# Altium schematic sheet GPS Board.SchDoc("GPS Board")
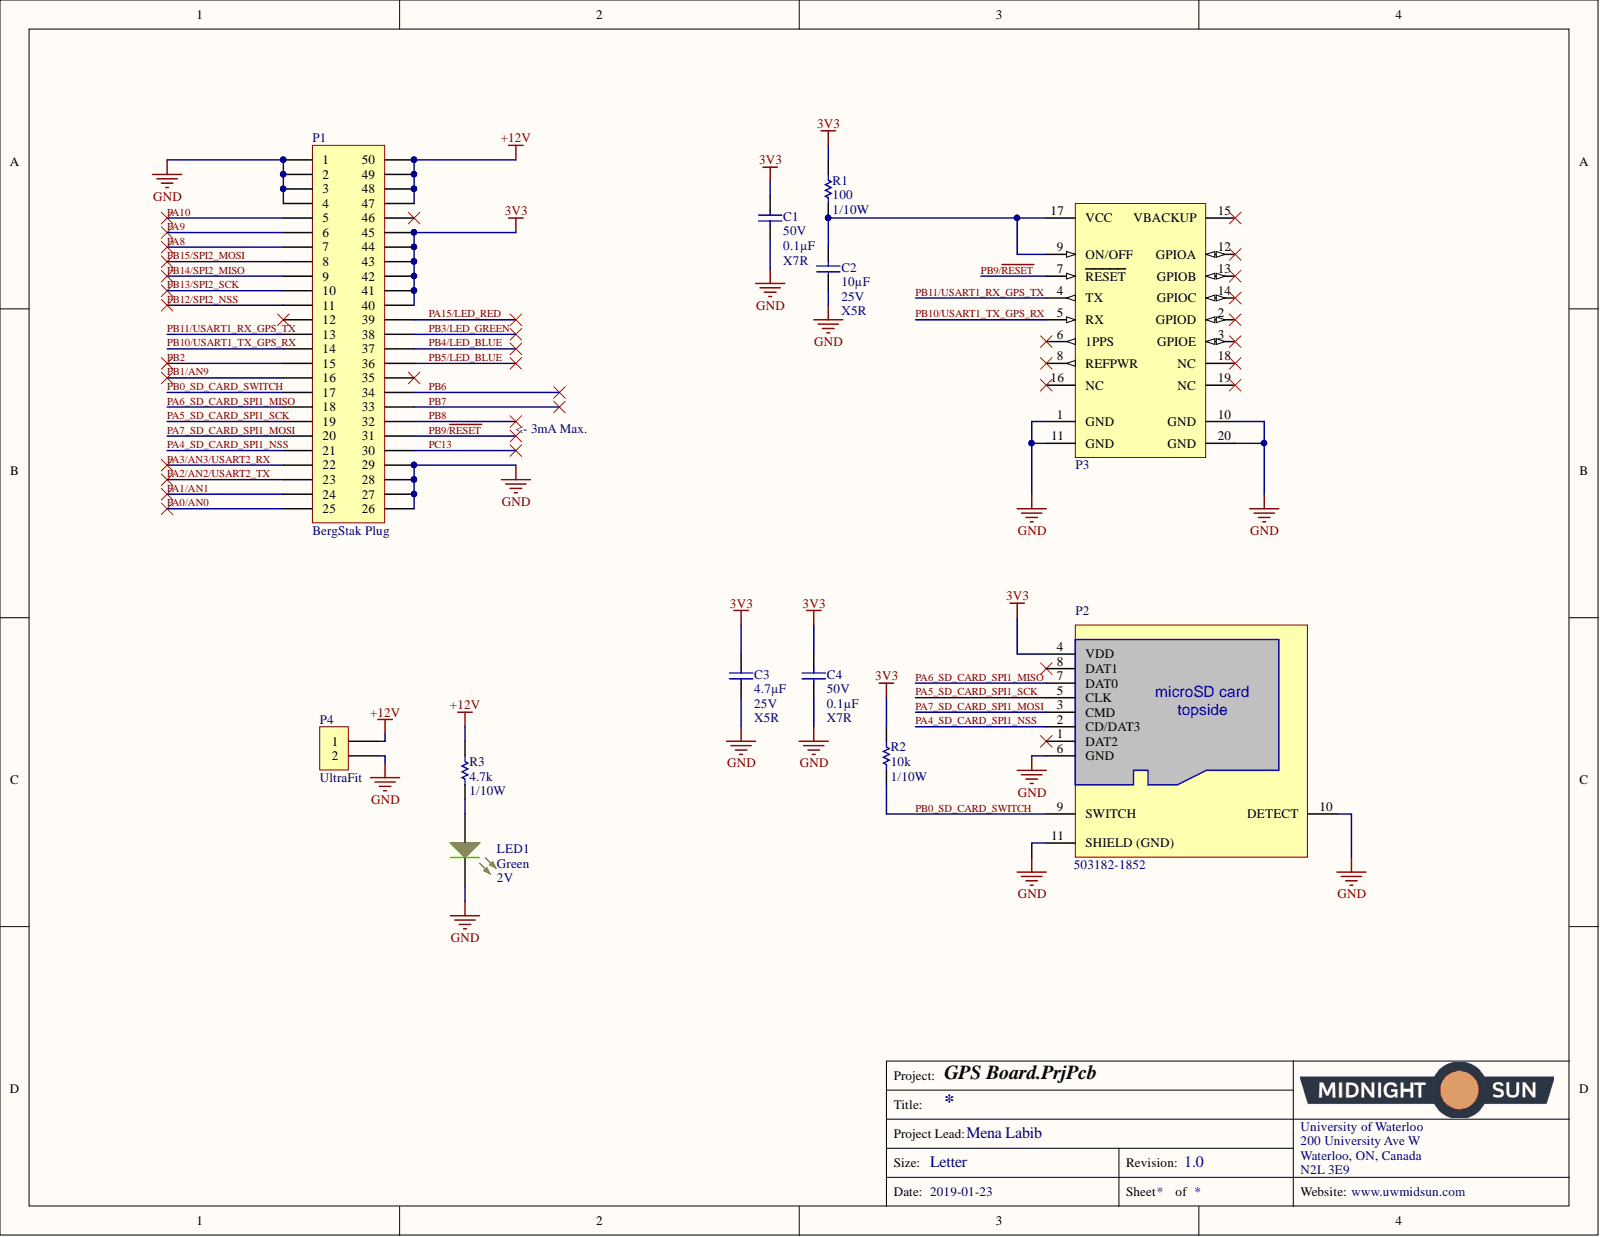
[diagram p0: GPS Board.SchDoc]

## Parts
C1  Voltage: 50V  Capacitance: 0.1µF  [CAP, 0603]  (hidden comment: CAP CER 0.1UF 50V 10% X7R 0603)
C2  Voltage: 25V  Temperature Coefficient: X5R  Capacitance: 10µF  [CAP, 0603]  (hidden comment: CAP CER 10uF 25V 20% X5R 0603)
C3  Voltage: 25V  Temperature Coefficient: X5R  Capacitance: 4.7µF  [CAP, 0603]  (hidden comment: CAP CER 4.7UF 25V 10% X5R 0603)
C4  Voltage: 50V  Temperature Coefficient: X7R  Capacitance: 0.1µF  [CAP, 0603]  (hidden comment: CAP CER 0.1UF 50V 10% X7R 0603)
LED1  Voltage - Forward (Vf) (Typ): 2V  Color: Green  [LED_0603]  (hidden comment: LED GREEN CLEAR 2V 0603)
P1  Series: BergStak Plug  [CONN, Bergstak 50 Pos Plug]  (hidden comment: CONN 50POS Bergstak Plug 0.02")
P2  Manufacturer Part Number: 503182-1852  [CONN MICRO-SD HOLDER MOLEX 503182-1852]  (hidden comment: CONN MICRO-SD ULTRA-LOW 8CKT)
P3  EVAL GPS EVM-GPS-F4  [EVM-GPS-F4]  (value not drawn)
P4  Series: UltraFit  [CONN, 2POS ULTRA-FIT]  (hidden comment: CONN 2POS ULTRA-FIT 0.138")
R1  Power: 1/10W  [RES, 0603]  (hidden comment: RES 100 OHM 1% 1/10W 0603)
R2  Resistance: 10k  Power: 1/10W  [RES, 0603]  (hidden comment: RES 10K OHM 1% 1/10W 0603)
R3  Resistance (Ohms): 4.7k  Power (Watts): 1/10W  [RES, 0603]  (hidden comment: RES 4.7K OHM 1% 1/10W 0603)

## Nets
3V3: C1.2 C3.1 C4.2 P1.40 P1.41 P1.42 P1.43 P1.44 P1.45 P2.4(VDD) R1.1 R2.2
+12V: P1.47 P1.48 P1.49 P1.50 P4.1 R3.1
GND: C1.1 C2.2 C3.2 C4.1 LED1.2 P1.1 P1.2 P1.3 P1.4 P1.26 P1.27 P1.28 P1.29 P2.6(GND) P2.10(DETECT) P2.11(SHIELD_(GND)) P3.1(GND) P3.10(GND) P3.11(GND) P3.20(GND) P4.2
PA0/AN0: P1.25
PA1/AN1: P1.24
PA2/AN2/USART2_TX: P1.23
PA3/AN3/USART2_RX: P1.22
PA4_SD_CARD_SPI1_NSS: P1.21 P2.2(CD/DAT3)
PA5_SD_CARD_SPI1_SCK: P1.19 P2.5(CLK)
PA6_SD_CARD_SPI1_MISO: P1.18 P2.7(DAT0)
PA7_SD_CARD_SPI1_MOSI: P1.20 P2.3(CMD)
PA8: P1.7
PA9: P1.6
PA10: P1.5
PA15/LED_RED: P1.39
PB0_SD_CARD_SWITCH: P1.17 P2.9(SWITCH) R2.1
PB1/AN9: P1.16
PB2: P1.15
PB3/LED_GREEN: P1.38
PB4/LED_BLUE: P1.37
PB5/LED_BLUE: P1.36
PB6: P1.34
PB7: P1.33
PB8: P1.32
PB9/~{RESET}: P1.31 P3.7(RESET)
PB10/USART1_TX_GPS_RX: P1.14 P3.5(RX)
PB11/USART1_RX_GPS_TX: P1.13 P3.4(TX)
PB12/SPI2_NSS: P1.11
PB13/SPI2_SCK: P1.10
PB14/SPI2_MISO: P1.9
PB15/SPI2_MOSI: P1.8
PC13: P1.30
NetC2_1: C2.1 P3.9(ON/OFF) P3.17(VCC) R1.2
NetLED1_1: LED1.1 R3.2

Not connected: P1 12 35 46; P2 1(DAT2) 8(DAT1); P3 2(GPIOD) 3(GPIOE) 6(1PPS) 8(REFPWR) 12(GPIOA) 13(GPIOB) 14(GPIOC) 15(VBACKUP) 16(NC) 18(NC) 19(NC)

## Library data of the parts
C1 C4 = CAP CER 0.1UF 50V 10% X7R 0603: 0.10µF ±10% 50V Ceramic Capacitor X7R 0603 (1608 Metric); Manufacturer Part Number 1: 06035C104KAT2A; Manufacturer 1: AVX Corporation; Tolerance: ±10%; Thickness (Max): 0.035" (0.90mm); Supplier Part Number 1: 478-5052-1-ND; Supplier 1: Digi-Key; Size / Dimension: 0.063" L x 0.032" W (1.60mm x 0.81mm); Ratings: -; Part Status: Active; Packaging: Cut Tape (CT); Package / Case: 0603 (1608 Metric); Operating Temperature: -55°C ~ 125°C; Mounting Type: Surface Mount, MLCC; Lead Style: -; Lead Spacing: -; Height - Seated (Max): -; ComponentLink1URL: http://datasheets.avx.com/X7RDielectric.pdf; ComponentLink1Description: http://datasheets.avx.com/X7RDielectric.pdf; Component Kind: Standard; Library Name: Schematic Diagrams.SchLib
  C1: Temperature Coefficient: X7R
C2 = CAP CER 10uF 25V 20% X5R 0603: 10µF ±20% 25V Ceramic Capacitor X5R 0603 (1608 Metric); Manufacturer Part Number 1: GRM188R61E106MA73D; Manufacturer 1: Murata Electronics North America; Tolerance: ±20%; Thickness (Max): 0.031" (0.80mm); Supplier Part Number 1: 490-7202-1-ND; Supplier 1: Digi-Key; Size / Dimension: 0.063" L x 0.031" W (1.60mm x 0.80mm); Ratings: -; Part Status: Active; Packaging: Cut Tape (CT); Package / Case: 0603 (1608 Metric); Operating Temperature: -55°C ~ 85°C; Mounting Type: Surface Mount, MLCC; Lead Style: -; Lead Spacing: -; Height - Seated (Max): -; ComponentLink1URL: http://www.murata.com/~/media/webrenewal/support/library/catalog/products/capacitor/mlcc/c02e.ashx; ComponentLink1Description: http://www.murata.com/~/media/webrenewal/support/library/catalog/products/capacitor/mlcc/c02e.ashx; Component Kind: Standard; Library Name: Schematic Diagrams.SchLib
C3 = CAP CER 4.7UF 25V 10% X5R 0603: 4.7µF ±10% 25V Ceramic Capacitor X5R 0603 (1608 Metric); Manufacturer Part Number 1: GRM188R61E475KE11D; Manufacturer 1: Murata Electronics North America; Tolerance: ±10%; Thickness (Max): 0.031" (0.80mm); Supplier Part Number 1: 490-7203-1-ND; Supplier 1: Digi-Key; Size / Dimension: 0.063" L x 0.031" W (1.60mm x 0.80mm); Ratings: -; Part Status: Active; Packaging: Cut Tape (CT); Package / Case: 0603 (1608 Metric); Operating Temperature: -55°C ~ 85°C; Mounting Type: Surface Mount, MLCC; Lead Style: -; Lead Spacing: -; Height - Seated (Max): -; ComponentLink1URL: http://www.murata.com/~/media/webrenewal/support/library/catalog/products/capacitor/mlcc/c02e.ashx; ComponentLink1Description: http://www.murata.com/~/media/webrenewal/support/library/catalog/products/capacitor/mlcc/c02e.ashx; Component Kind: Standard
LED1 = LED GREEN CLEAR 2V 0603: Green 572nm LED Indication - Discrete 2V 0603 (1608 Metric); Manufacturer Part Number: 150060VS75000; Manufacturer: Wurth Electronics Inc.; Wavelength - Dominant: 570nm; Viewing Angle: 140°; Millicandela Rating: 40mcd; Lens Style/Size: Rectangle with Flat Top, 1.10mm x 0.70mm; Lens Color: Colorless; Current - Test: 20mA; Wavelength - Peak: 572nm; Supplier Part Number 1: 732-4980-1-ND; Supplier Device Package: 0603; Supplier 1: Digi-Key; Stock 1: 9408; Size / Dimension: 1.60mm L x 0.80mm W; RoHS: RoHS Compliant; Pricing 1: 1=0.21, 100=0.1617, 500=0.13964 (CAD); Part Status: Active; Packaging: Cut Tape (CT); Package / Case: 0603 (1608 Metric); Mounting Type: Surface Mount; Lens Transparency: Clear; Height (Max): 0.80mm; Features: -; ComponentLink1URL: http://katalog.we-online.de/led/datasheet/150060VS75000.pdf; ComponentLink1Description: http://katalog.we-online.de/led/datasheet/150060VS75000.pdf; Component Kind: Standard; Category: Optoelectronics; Library Name: Schematic Diagrams.SchLib
P1 = CONN 50POS Bergstak Plug 0.02": 50 Position Connector Plug, Outer Shroud Contacts Surface Mount Gold; Manufacturer Part Number 1: 10132797-055100LF; Manufacturer 1: Amphenol FCI; Pitch: 0.020" (0.50mm); Number of Positions: 50; Mated Stacking Heights: 5mm; Supplier Part Number 1: 609-5226-1-ND; Supplier 1: Digi-Key; Part Status: Active; Packaging: Cut Tape (CT); Number of Rows: 2; Mounting Type: Surface Mount; Height Above Board: 0.169" (4.30mm); Contact Finish Thickness: 1µin (0.025µm); Contact Finish: Gold; Connector Type: Plug, Outer Shroud Contacts; ComponentLink1URL: http://portal.fciconnect.com/Comergent//fci/drawing/10132797.pdf; ComponentLink1Description: http://portal.fciconnect.com/Comergent//fci/drawing/10132797.pdf; Component Kind: Standard; Library Name: Schematic Diagrams.SchLib
P2 = CONN MICRO-SD ULTRA-LOW 8CKT: 9 (8 + 1) Position Card Connector microSD™ Surface Mount, Right Angle Gold; Manufacturer: Molex; Pitch: 1.1mm; Number of Circuits: 8; Gender: Male; Termination: Solder; Supplier Part Number 1: WM12834CT-ND; Supplier 1: Digi-Key; Stock 1: 36042; RoHS: Yes; REACH SVHC: No SVHC; Pricing 1: 1 = 2.45000, 10 = 2.22400, 25 = 2.08840, 50 = 1.99740, 100 = 1.90670, 250 = 1.72508, 500 = 1.58890 (USD); Packaging: Tape and Reel; Package Quantity: 1600; Orientation: Right Angle; Number of Rows: 1; Mount: Surface Mount; Min Operating Temperature: -25°C; Max Operating Temperature: 85°C; Length: 15.2mm; Housing Material: Polymer; Halogen Free: Low Halogen; Depth: 14.95mm; Contact Plating: Gold; Contact Material: Copper Alloy; Connector Type: Connector; Component Kind: Standard
P3 = EVAL GPS EVM-GPS-F4: BOARD EVAL FOR RXM-GPS-F4; Manufacturer 1: Linx Technologies Inc.; Frequency: 1575.42MHz; Type: GPS; Supplier Part Number 1: EVM-GPS-F4-ND; Supplier 1: Digi-Key; Supplied Contents: Board; Part Status: Active; Manufacturer Part Number 1: EVM-GPS-F4; For Use With/Related Products: RXM-GPS-F4; ComponentLink1URL: https://www.linxtechnologies.com/resources/data-guides/man-evm-gps-f4.pdf; ComponentLink1Description: https://www.linxtechnologies.com/resources/data-guides/man-evm-gps-f4.pdf; Component Kind: Standard; Library Name: Schematic Diagrams.SchLib
P4 = CONN 2POS ULTRA-FIT 0.138": 2 Positions Header, Shrouded Connector 0.138" (3.50mm) Through Hole Gold; Manufacturer Part Number 1: 1722861302; Manufacturer 1: Molex, LLC; Voltage: 400V; Style: Board to Board or Cable; Shrouding: Shrouded - 4 Wall; Number of Positions Loaded: All; Number of Positions: 2; Material Flammability Rating: UL94 V-0; Mated Stacking Heights: 26.99mm; Contact Length - Post: 0.126" (3.20mm); Termination: Kinked Pin, Solder; Supplier Part Number 1: WM11673-ND; Supplier 1: Digi-Key; Row Spacing - Mating: -; Pitch - Mating: 0.138" (3.50mm); Part Status: Active; Packaging: Tray; Overall Contact Length: -; Operating Temperature: -40°C ~ 120°C; Number of Rows: 1; Mounting Type: Through Hole; Insulation Material: Liquid Crystal Polymer (LCP); Insulation Height: 0.465" (11.80mm); Insulation Color: Black; Ingress Protection: -; Fastening Type: Latch Holder; Current Rating: -; Contact Type: Male Pin; Contact Shape: Square; Contact Material: Copper Alloy; Contact Length - Mating: -; Contact Finish Thickness - Post: 60µin (1.52µm); Contact Finish Thickness - Mating: 30µin (0.76µm); Contact Finish - Post: Tin; Contact Finish - Mating: Gold; Connector Type: Header; ComponentLink1URL: http://www.molex.com/pdm_docs/sd/1722861302_sd.pdf; ComponentLink1Description: http://www.molex.com/pdm_docs/sd/1722861302_sd.pdf; Component Kind: Standard; Library Name: Schematic Diagrams.SchLib
R1 = RES 100 OHM 1% 1/10W 0603: 100 Ohm ±1% 0.1W, 1/10W Chip Resistor 0603 (1608 Metric) Moisture Resistant Thick Film; Manufacturer Part Number 1: RC0603FR-07100RL; Manufacturer 1: Yageo; Temperature Coefficient: ±100ppm/°C; Resistance: 100; Tolerance: ±1%; Supplier Part Number 1: 311-100HRCT-ND; Supplier Device Package: 0603; Supplier 1: Digi-Key; Size / Dimension: 0.063" L x 0.031" W (1.60mm x 0.80mm); Part Status: Active; Packaging: Cut Tape (CT); Package / Case: 0603 (1608 Metric); Operating Temperature: -55°C ~ 155°C; Height - Seated (Max): 0.022" (0.55mm); Failure Rate: -; Composition: Thick Film; ComponentLink1URL: http://www.yageo.com/documents/recent/PYu-RC_Group_51_RoHS_L_7.pdf; ComponentLink1Description: http://www.yageo.com/documents/recent/PYu-RC_Group_51_RoHS_L_7.pdf; Component Kind: Standard; Library Name: Schematic Diagrams.SchLib
R2 = RES 10K OHM 1% 1/10W 0603: RES SMD 10K OHM 1% 1/10W 0603; Manufacturer Part Number 1: RC0603FR-0710KL; Manufacturer 1: Yageo; Temperature Coefficient: ±100ppm/°C; Tolerance: ±1%; Supplier Part Number 1: 311-10.0KHRCT-ND; Supplier Device Package: 0603; Supplier 1: Digi-Key; Size / Dimension: 0.063" L x 0.031" W (1.60mm x 0.80mm); Part Status: Active; Packaging: Cut Tape (CT); Package / Case: 0603 (1608 Metric); Operating Temperature: -55°C ~ 155°C; Height - Seated (Max): 0.022" (0.55mm); Failure Rate: -; Composition: Thick Film; ComponentLink1URL: http://www.yageo.com/documents/recent/PYu-RC_Group_51_RoHS_L_7.pdf; ComponentLink1Description: http://www.yageo.com/documents/recent/PYu-RC_Group_51_RoHS_L_7.pdf; Component Kind: Standard
R3 = RES 4.7K OHM 1% 1/10W 0603: 4.7k Ohm ±1% 0.1W, 1/10W Chip Resistor 0603 (1608 Metric) Moisture Resistant Thick Film; Manufacturer Part Number 1: RC0603FR-074K7L; Manufacturer 1: Yageo; Temperature Coefficient: ±100ppm/°C; Description 1: RES SMD 4.7K OHM 1% 1/10W 0603; Tolerance: ±1%; Supplier Part Number 1: 311-4.70KHRCT-ND; Supplier Device Package: 0603; Supplier 1: Digi-Key; Stock 1: 3487566; Size / Dimension: 0.063" L x 0.031" W (1.60mm x 0.80mm); RoHS: RoHS Compliant; Pricing 1: 1=0.16, 10=0.022, 100=0.0089, 1000=0.00402, 2500=0.00349 (CAD); Part Status: Active; Packaging: Cut Tape (CT); Package / Case: 0603 (1608 Metric); Operating Temperature: -55°C ~ 155°C; Height - Seated (Max): 0.022" (0.55mm); Features: Moisture Resistant; Failure Rate: -; Composition: Thick Film; ComponentLink1URL: http://www.yageo.com/documents/recent/PYu-RC_Group_51_RoHS_L_7.pdf; ComponentLink1Description: http://www.yageo.com/documents/recent/PYu-RC_Group_51_RoHS_L_7.pdf; Component Kind: Standard; Category: Resistors; Library Name: Schematic Diagrams.SchLib
